# Altium schematic sheet BMS_IC.SchDoc
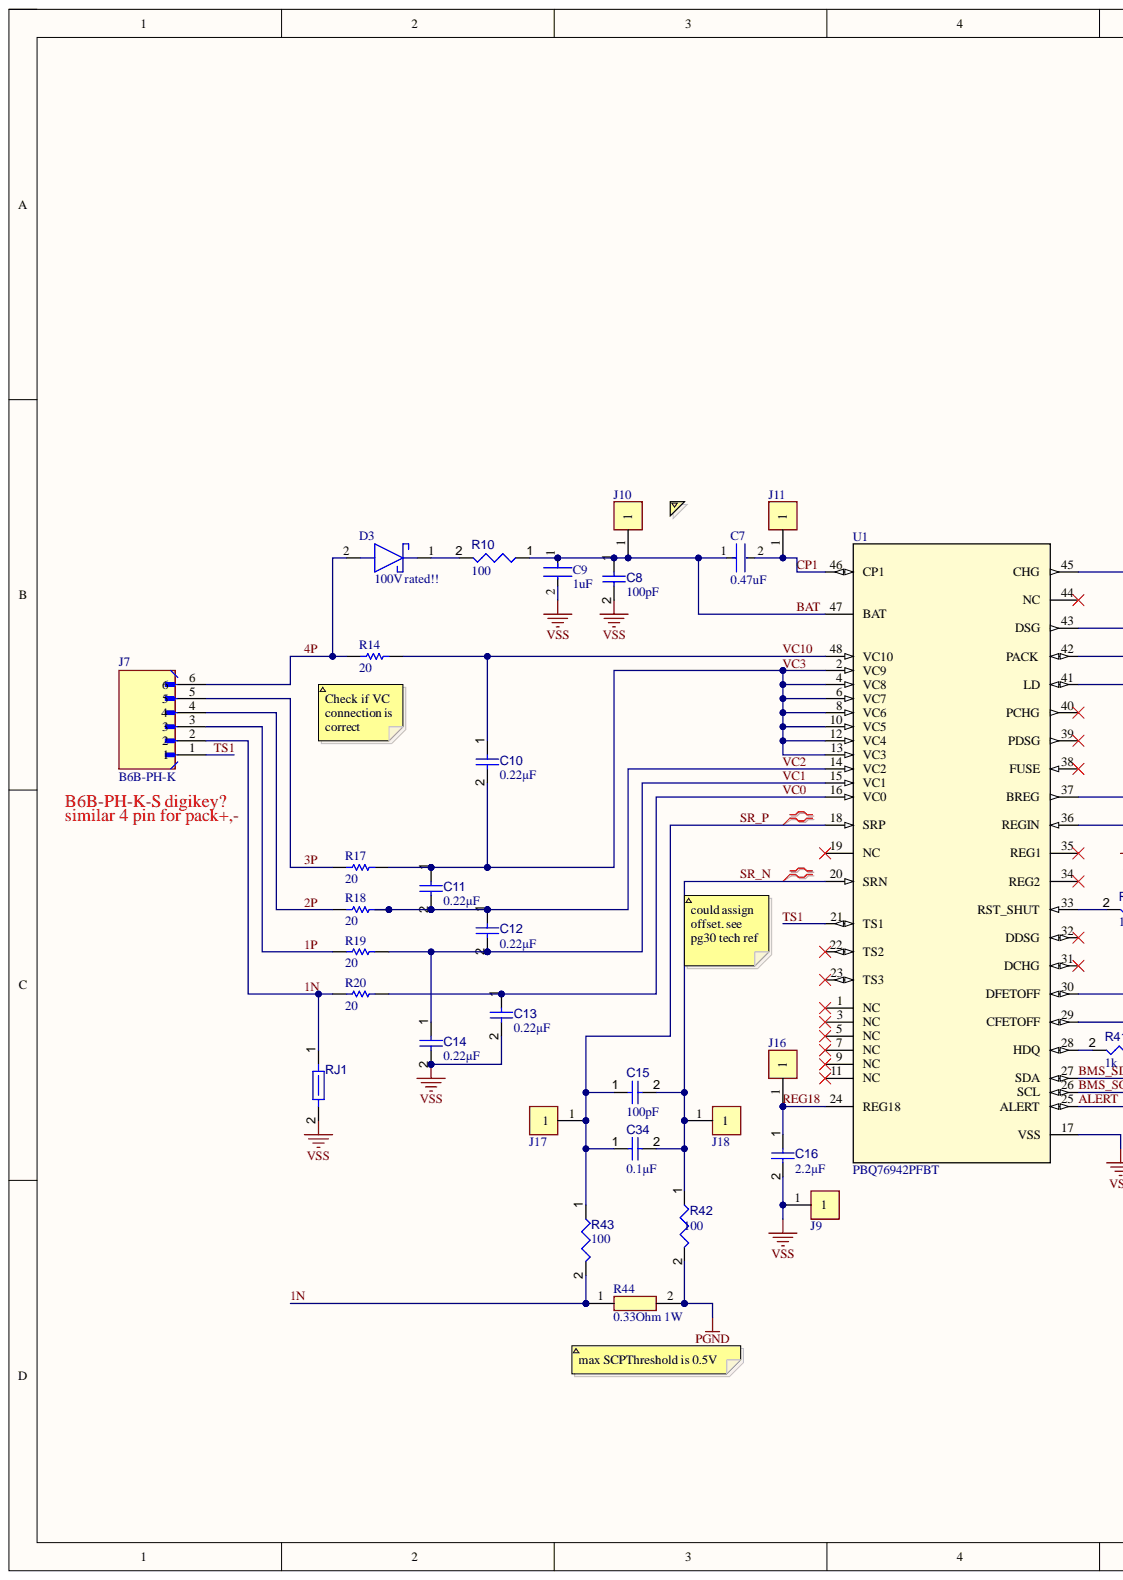
[diagram p0: BMS_IC.SchDoc, left]
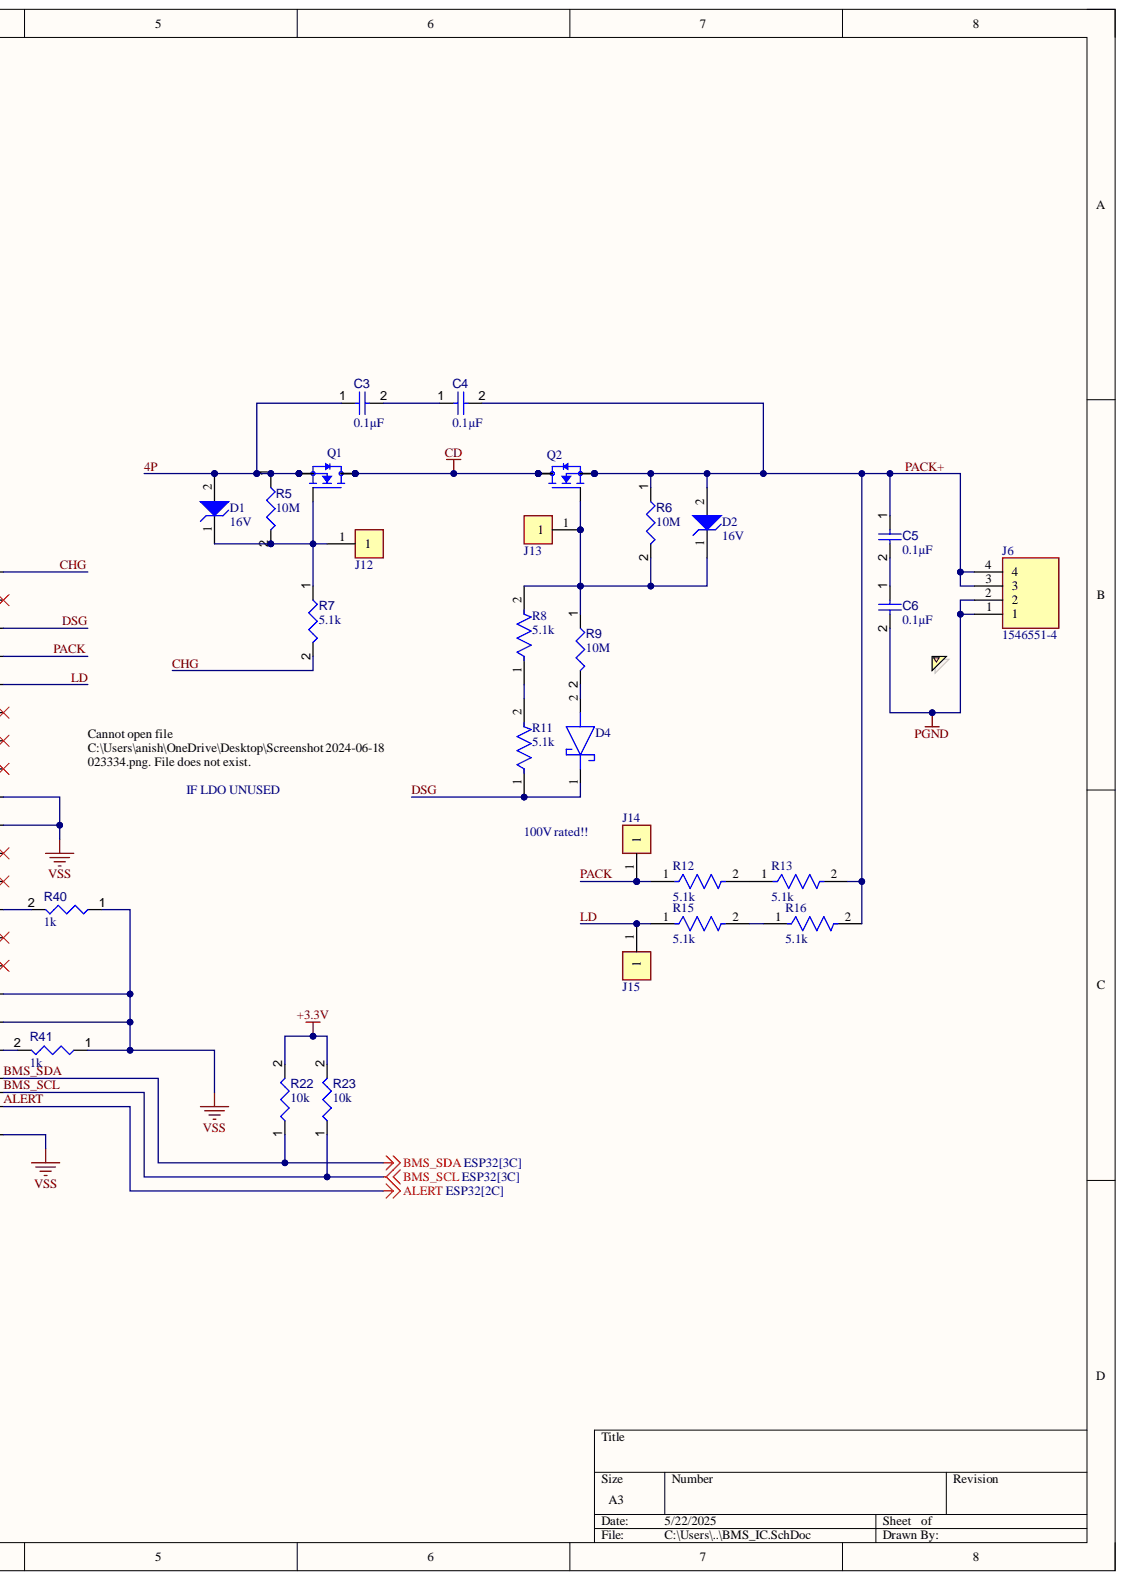
[diagram p1: BMS_IC.SchDoc, right]

## Parts
C3  value 0.1µF  [CAPC1608X94X35NL15T15]  (hidden comment: Capacitor 1 uF +/- 10% 16 V 0603)
C4  value 0.1µF  [CAPC1608X94X35NL15T15]  (hidden comment: Capacitor 1 uF +/- 10% 16 V 0603)
C5  value 0.1µF  [CAPC1608X94X35NL15T15]  (hidden comment: Capacitor 1 uF +/- 10% 16 V 0603)
C6  value 0.1µF  [CAPC1608X94X35NL15T15]  (hidden comment: Capacitor 1 uF +/- 10% 16 V 0603)
C7  0.47uF  [FP-GCM219-0_15-MFG]
C8  value 100pF  [CAPC1608X94X35NL15T15]  (hidden comment: Capacitor 1 uF +/- 10% 16 V 0603)
C9  1uF  [FP-C3216-160-0_2-IPC_B]
C10  value 0.22µF  [CAPC1608X94X35NL15T15]  (hidden comment: Capacitor 1 uF +/- 10% 16 V 0603)
C11  value 0.22µF  [CAPC1608X94X35NL15T15]  (hidden comment: Capacitor 1 uF +/- 10% 16 V 0603)
C12  value 0.22µF  [CAPC1608X94X35NL15T15]  (hidden comment: Capacitor 1 uF +/- 10% 16 V 0603)
C13  value 0.22µF  [CAPC1608X94X35NL15T15]  (hidden comment: Capacitor 1 uF +/- 10% 16 V 0603)
C14  value 0.22µF  [CAPC1608X94X35NL15T15]  (hidden comment: Capacitor 1 uF +/- 10% 16 V 0603)
C15  value 100pF  [CAPC1608X94X35NL15T15]  (hidden comment: Capacitor 1 uF +/- 10% 16 V 0603)
C16  value 2.2µF  [CAPC1608X94X35NL15T15]  (hidden comment: Capacitor 1 uF +/- 10% 16 V 0603)
C34  value 0.1µF  [CAPC1608X94X35NL15T15]  (hidden comment: Capacitor 1 uF +/- 10% 16 V 0603)
D1  16V  [ONSC-SOD-123-2-425-04_V]
D2  16V  [ONSC-SOD-123-2-425-04_V]
D3  SMD110PL-TP  [SODFL3616X135N]  (value not drawn)
D4  SMD110PL-TP  [SODFL3616X135N]  (value not drawn)
J6  1546551-4  [SHDR4W91P0X350_1X4_1400X730X875P]
J7  B6B-PH-K  [JST_B6B-PH-K]
J9  5001  [FP-5001-MFG]  (value not drawn)
J10  5001  [FP-5001-MFG]  (value not drawn)
J11  5001  [FP-5001-MFG]  (value not drawn)
J12  5001  [FP-5001-MFG]  (value not drawn)
J13  5001  [FP-5001-MFG]  (value not drawn)
J14  5001  [FP-5001-MFG]  (value not drawn)
J15  5001  [FP-5001-MFG]  (value not drawn)
J16  5001  [FP-5001-MFG]  (value not drawn)
J17  5001  [FP-5001-MFG]  (value not drawn)
J18  5001  [FP-5001-MFG]  (value not drawn)
Q1  TSM180N03CS_RLG  [SOP127P600X200-8N]  (value not drawn)
Q2  TSM180N03CS_RLG  [SOP127P600X200-8N]  (value not drawn)
R5  value 10M  [RESC1608X55X25ML10T15]  (hidden comment: Resistor 10k +/-1% 0603 100 mW)
R6  value 10M  [RESC1608X55X25ML10T15]  (hidden comment: Resistor 10k +/-1% 0603 100 mW)
R7  value 5.1k  [RESC1608X55X25ML10T15]  (hidden comment: Resistor 10k +/-1% 0603 100 mW)
R8  5.1k  [FP-CRCW1206-e3-IPC_A]
R9  value 10M  [RESC1608X55X25ML10T15]  (hidden comment: Resistor 10k +/-1% 0603 100 mW)
R10  value 100  [RESC1608X55X25ML10T15]  (hidden comment: Resistor 10k +/-1% 0603 100 mW)
R11  5.1k  [FP-CRCW1206-e3-IPC_A]
R12  5.1k  [FP-CRCW1206-e3-IPC_A]
R13  5.1k  [FP-CRCW1206-e3-IPC_A]
R14  20  [RESC3216X60X45ML10T20]
R15  5.1k  [FP-CRCW1206-e3-IPC_A]
R16  5.1k  [FP-CRCW1206-e3-IPC_A]
R17  20  [RESC3216X60X45ML10T20]
R18  20  [RESC3216X60X45ML10T20]
R19  20  [RESC3216X60X45ML10T20]
R20  20  [RESC3216X60X45ML10T20]
R22  value 10k  [RESC1608X55X25ML10T15]  (hidden comment: Resistor 10k +/-1% 0603 100 mW)
R23  value 10k  [RESC1608X55X25ML10T15]  (hidden comment: Resistor 10k +/-1% 0603 100 mW)
R40  value 1k  [RESC1608X55X25ML10T15]  (hidden comment: Resistor 10k +/-1% 0603 100 mW)
R41  value 1k  [RESC1608X55X25ML10T15]  (hidden comment: Resistor 10k +/-1% 0603 100 mW)
R42  value 100  [RESC1608X55X25ML10T15]  (hidden comment: Resistor 10k +/-1% 0603 100 mW)
R43  value 100  [RESC1608X55X25ML10T15]  (hidden comment: Resistor 10k +/-1% 0603 100 mW)
R44  0.33Ohm 1W  [ER1TR_(2512)]
RJ1  Net Tie 12x8mil  [SHORT06-FP]  (value not drawn)
U1  PBQ76942PFBT  [PFB0048A_N]

## Nets
+3.3V: R22.2 R23.2
CD: Q1.5 Q1.6 Q1.7 Q1.8 Q2.5 Q2.6 Q2.7 Q2.8
PGND: C6.2 J6.1 J6.2 R42.2 R44.2
VSS: C8.2 C9.2 C13.2 C14.2 C16.2 J9.1 R40.1 R41.1 RJ1.2 U1.17(VSS) U1.29(CFETOFF) U1.30(DFETOFF) U1.36(REGIN) U1.37(BREG)
1N: J7.2 R20.1 R43.2 R44.1 RJ1.1
1P: J7.3 R19.1
2P: J7.4 R18.1
3P: J7.5 R17.1
4P: C3.1 D1.2 D3.2 J7.6 Q1.1 Q1.2 Q1.3 R5.1 R14.1
ALERT: U1.25(ALERT)
BAT: C7.1 C8.1 C9.1 J10.1 R10.1 U1.47(BAT)
BMS_SCL: R23.1 U1.26(SCL)
BMS_SDA: R22.1 U1.27(SDA)
CHG: R7.2 U1.45(CHG)
CP1: C7.2 J11.1 U1.46(CP1)
DSG: D4.1 R11.1 U1.43(DSG)
LD: J15.1 R15.1 U1.41(LD)
PACK: J14.1 R12.1 U1.42(PACK)
PACK+: C4.2 C5.1 D2.2 J6.3 J6.4 Q2.1 Q2.2 Q2.3 R6.1 R13.2 R16.2
REG18: C16.1 J16.1 U1.24(REG18)
SR_N: C15.2 C34.2 J18.1 R42.1 U1.20(SRN)
SR_P: C15.1 C34.1 J17.1 R43.1 U1.18(SRP)
TS1: J7.1 U1.21(TS1)
VC0: C13.1 R20.2 U1.16(VC0)
VC1: C12.2 C14.1 R19.2 U1.15(VC1)
VC2: C11.2 C12.1 R18.2 U1.14(VC2)
VC3: C10.2 C11.1 R17.2 U1.2(VC9) U1.4(VC8) U1.6(VC7) U1.8(VC6) U1.10(VC5) U1.12(VC4) U1.13(VC3)
VC10: C10.1 R14.2 U1.48(VC10)
NetC3_2: C3.2 C4.1
NetC5_2: C5.2 C6.1
NetD1_1: D1.1 J12.1 Q1.4 R5.2 R7.1
NetD2_1: D2.1 J13.1 Q2.4 R6.2 R8.2 R9.1
NetD3_1: D3.1 R10.2
NetD4_2: D4.2 R9.2
NetR8_1: R8.1 R11.2
NetR12_2: R12.2 R13.1
NetR15_2: R15.2 R16.1
NetR40_2: R40.2 U1.33(RST_SHUT)
NetR41_2: R41.2 U1.28(HDQ)

Not connected: U1 1(NC) 3(NC) 5(NC) 7(NC) 9(NC) 11(NC) 19(NC) 22(TS2) 23(TS3) 31(DCHG) 32(DDSG) 34(REG2) 35(REG1) 38(FUSE) 39(PDSG) 40(PCHG) 44(NC)

## Library data of the parts
C3-C6 C8 C10-C16 C34 = CMP-001-00003-6: Chip Capacitor, 1 uF, +/- 10%, 16 V, 0603 (1608 Metric); Capacitor Type: Ceramic; Voltage Rating: 16V; Case/Package: 0603; Component Kind: Standard; Max Operating Temperature: 125°C; Min Operating Temperature: -55°C; Mounting Technology: SM; Pins: 2; RoHS Compliant: True; Tolerance: 10%; Library Name: University of New South Wales
C7 = CMP-2007-00089-2: Ceramic Capacitor for Automotive 470nF  ±10% 25VDC X7R 0805 Paper T/R; Voltage Rating: 25V; Capacitance: 470nF; Case Code (Imperial): 0805; Case Code (Metric): 2012; Case/Package: 0805; Component Kind: Standard; Depth: 1.25mm; Dielectric: X7R; Height: 0.85mm; Lead Free: Lead Free; Length: 2mm; Library Name: Altium Content Vault; Max Operating Temperature: 125°C; Min Operating Temperature: -55°C; Mount: Surface Mount; Package Quantity: 1; Packaging: Tape and Reel; Radiation Hardening: No; Ratings: AEC-Q200; Termination: SMD/SMT; Thickness: 0.037inch; Tolerance: 10%; Voltage Rating (DC): 25V; Width: 0.049inch
C9 = CMP-2000-06049-2: Multilayer Ceramic Capacitors 1uF ±10% 100V X7R SMD 1206; Capacitance: 1uF; Case Code (Imperial): 1206; Case Code (Metric): 3216; Case/Package: 1206; Component Kind: Standard; Construction: Flat; Contact Plating: Tin; Depth: 1.6mm; Dielectric: X7R; Height: 1.6mm; Lead Free: Lead Free; Length: 3.2mm; Library Name: Altium Content Vault; Max Operating Temperature: 125°C; Min Operating Temperature: -55°C; Mount: Surface Mount; Package Quantity: 1; Packaging: Tape and Reel; REACH SVHC: No SVHC; Resistor Type: Ceramic; RoHS Compliant: Yes; Series: C; Termination: SMD/SMT; Thickness: 0.051inch; Tolerance: 10%; Voltage: 100V; Voltage Rating: 100V; Voltage Rating (DC): 100V; Weight: 0.000571oz; Width: 0.063inch
D1 D2 = CMP-1060-00533-1: Zener Voltage Regulator, 500 mW, 2-Pin SOD-123, Pb-Free, Tape and Reel; Manufacturer: On Semiconductor; PartNumber: MMSZ5246BT1G; P Max (W): 0.5; VZ Typ (V): 16; Component Kind: Standard; ComponentLink1Description: Manufacturer URL; ComponentLink1URL: http://www.onsemi.com/; ComponentLink2Description: Datasheet; ComponentLink2URL: http://www.onsemi.com/pub_link/Collateral/MMSZ5221BT1-D.PDF; ComponentLink3Description: Package Specification; ComponentLink3URL: http://www.onsemi.com/pub_link/Collateral/425-04.PDF; DatasheetVersion: Rev. 12; Library Name: Altium Content Vault; Mounting Technology: Surface Mount; PackageDescription: 2-Pin SOD, Body 1.6 x 2.69 mm; PackageReference: SOD-123-2-425-04; PackageVersion: Rev. G, 10/2009; Packing: Tape and Reel; RoHS: Pb-Free
D3 D4 = SMD110PL-TP: Schottky Diode; Manufacturer_Name: MCC; Arrow Part Number: SMD110PL-TP; Arrow Price/Stock: https://www.arrow.com/en/products/smd110pl-tp/micro-commercial-components?region=asia; Component Kind: Standard; Datasheet Link: https://www.mccsemi.com/pdf/Products/SMD15PL-SMD1200PL(SOD-123FL).pdf; Height: 1.35mm; Manufacturer_Part_Number: SMD110PL-TP; Mouser Part Number: 833-SMD110PL-TP; Mouser Price/Stock: https://www.mouser.co.uk/ProductDetail/Micro-Commercial-Components-MCC/SMD110PL-TP?qs=xjxbgUsuSIBtRX%252BtbqnRwQ%3D%3D
J6 = 1546551-4: Connector; Manufacturer_Name: TE Connectivity; Arrow Part Number: 1546551-4; Arrow Price/Stock: https://www.arrow.com/en/products/1546551-4/te-connectivity?region=europe; Component Kind: Standard; Datasheet Link: https://www.te.com/commerce/DocumentDelivery/DDEController?Action=srchrtrv&DocNm=1-1773458-1_EURO_STYLE_QRG&DocType=Data%20Sheet&DocLang=English&PartCntxt=1546551-4&DocFormat=pdf; Height: 8.75mm; Manufacturer_Part_Number: 1546551-4; Mouser Part Number: 571-1546551-4; Mouser Price/Stock: https://www.mouser.co.uk/ProductDetail/TE-Connectivity/1546551-4?qs=m%2FhmcwQ75LnAjOUb0MU8sQ%3D%3D
J7 = B6B-PH-K: MF: {'manufacturer': u'JST Corporation'}; Availability: Unavailable; Component Kind: Standard; MP: B6B-PH-K; Package: None; Price: None
J9-J18 = CMP-2000-05181-2: PC TEST POINT MINIATURE BLACK; Body Material: Bronze; Hole Diameter: 1.6mm; Inside Diameter: 1.27mm; Insulation: Insulated; Number of Contacts: 1; Outside Diameter: 2.54mm; Plating: Silver; Color: Black; Component Kind: Standard; Contact Material: Bronze; Contact Plating: Silver; Diameter: 2.54mm; Flammability Rating: UL94 V-0; Height: 0.3inch; Housing Color: Black; Insulation Material: Nylon; Lead Free: Lead Free; Length: 7.62mm; Library Name: Altium Content Vault; Material: Nylon; Mount: Through Hole; Number of Ports: 1; Orientation: Straight; Package Quantity: 100; Packaging: Bulk; REACH SVHC: No SVHC; RoHS Compliant: Yes; Series: THM; Termination: Through Hole
Q1 Q2 = TSM180N03CS_RLG: MF: {'manufacturer': u'Taiwan Semiconductor'}; Availability: Unavailable; Component Kind: Standard; MP: TSM180N03CS_RLG; Package: None; Price: None
R5-R7 R9 R10 R22 R23 R40-R43 = CMP-009-00017-5: Chip Resistor, 10 KOhm, +/- 1%, 01 W, -55 to 155 degC, 0603 (1608 Metric); Power: 100mW; Voltage Rating: 50V; Case/Package: 0603; Component Kind: Standard; Max Operating Temperature: 155°C; Min Operating Temperature: -55°C; Mounting Technology: SM; Pins: 2; RoHS Compliant: True; Tolerance: 1%; Library Name: University of New South Wales
R8 R11-R13 R15 R16 = CMP-2003-02296-2: RES Thick Film, 5.1kΩ, 5%, 0.25W, 200ppm/°C, 1206; Max Power Dissipation: 250mW; Resistance: 5.1kR; Voltage Rating: 200V; Case Code (Imperial): 1206; Case Code (Metric): 3216; Case/Package: 1206; Component Kind: Standard; Composition: Thick Film; Contact Plating: Tin; Depth: 1.6mm; Features: Automotive AEC-Q200; Height: 0.55mm; Lead Free: Lead Free; Length: 3.2mm; Library Name: Altium Content Vault; Max Operating Temperature: 155°C; Military Standard: Not; Min Operating Temperature: -55°C; Number of Terminations: 2; Package Quantity: 1; Packaging: Tape and Reel; Power Rating: 250mW; Radiation Hardening: No; Temperature Coefficient: 200ppm/°C; Termination: SMD/SMT; Tolerance: 5%; Voltage Rating (DC): 200V; Weight: 0.000353oz; Width: 0.063inch; Working Voltage: 200V
R14 R17-R20 = CMP-2003-01944-1: Manufacturer: Vishay Dale; Part Number: CRCW120620R0FKEA; Component Kind: Standard; Library Name: Altium Content Vault
R44 = ERJ-1TRQFR33U: Resistor  KRL3264E-C-R330-F-T1; Manufacturer_Name: Panasonic; Arrow Part Number: ERJ-1TRQFR33U; Arrow Price/Stock: http://www.arrow.com/en/products/erj-1trqfr33u/panasonic; Component Kind: Standard; Datasheet Link: http://industrial.panasonic.com/cdbs/www-data/pdf/RDN0000/AOA0000C313.pdf; Height: 0.7mm; Manufacturer_Part_Number: ERJ-1TRQFR33U; Mouser Part Number: 667-ERJ-1TRQFR33U; Mouser Price/Stock: https://www.mouser.co.uk/ProductDetail/Panasonic/ERJ-1TRQFR33U?qs=KH2o3k57USg2VBeJMlUpYA%3D%3D
RJ1 = CMP-009-00121-2: Component Kind: Net Tie; Description: Net Tie 12x8mil; Max Operating Temperature: 155°C; Min Operating Temperature: -55°C; Pins: 2; Library Name: University of New South Wales
U1 = PBQ76942PFBT: No Description Available; Mfr_Name: Texas Instruments; Component Kind: Standard; Library Name: Schlib1.SchLib; RefDes: RefDes; TYPE: TYPE
